# Altium schematic sheet RTLS_Sch.SchDoc
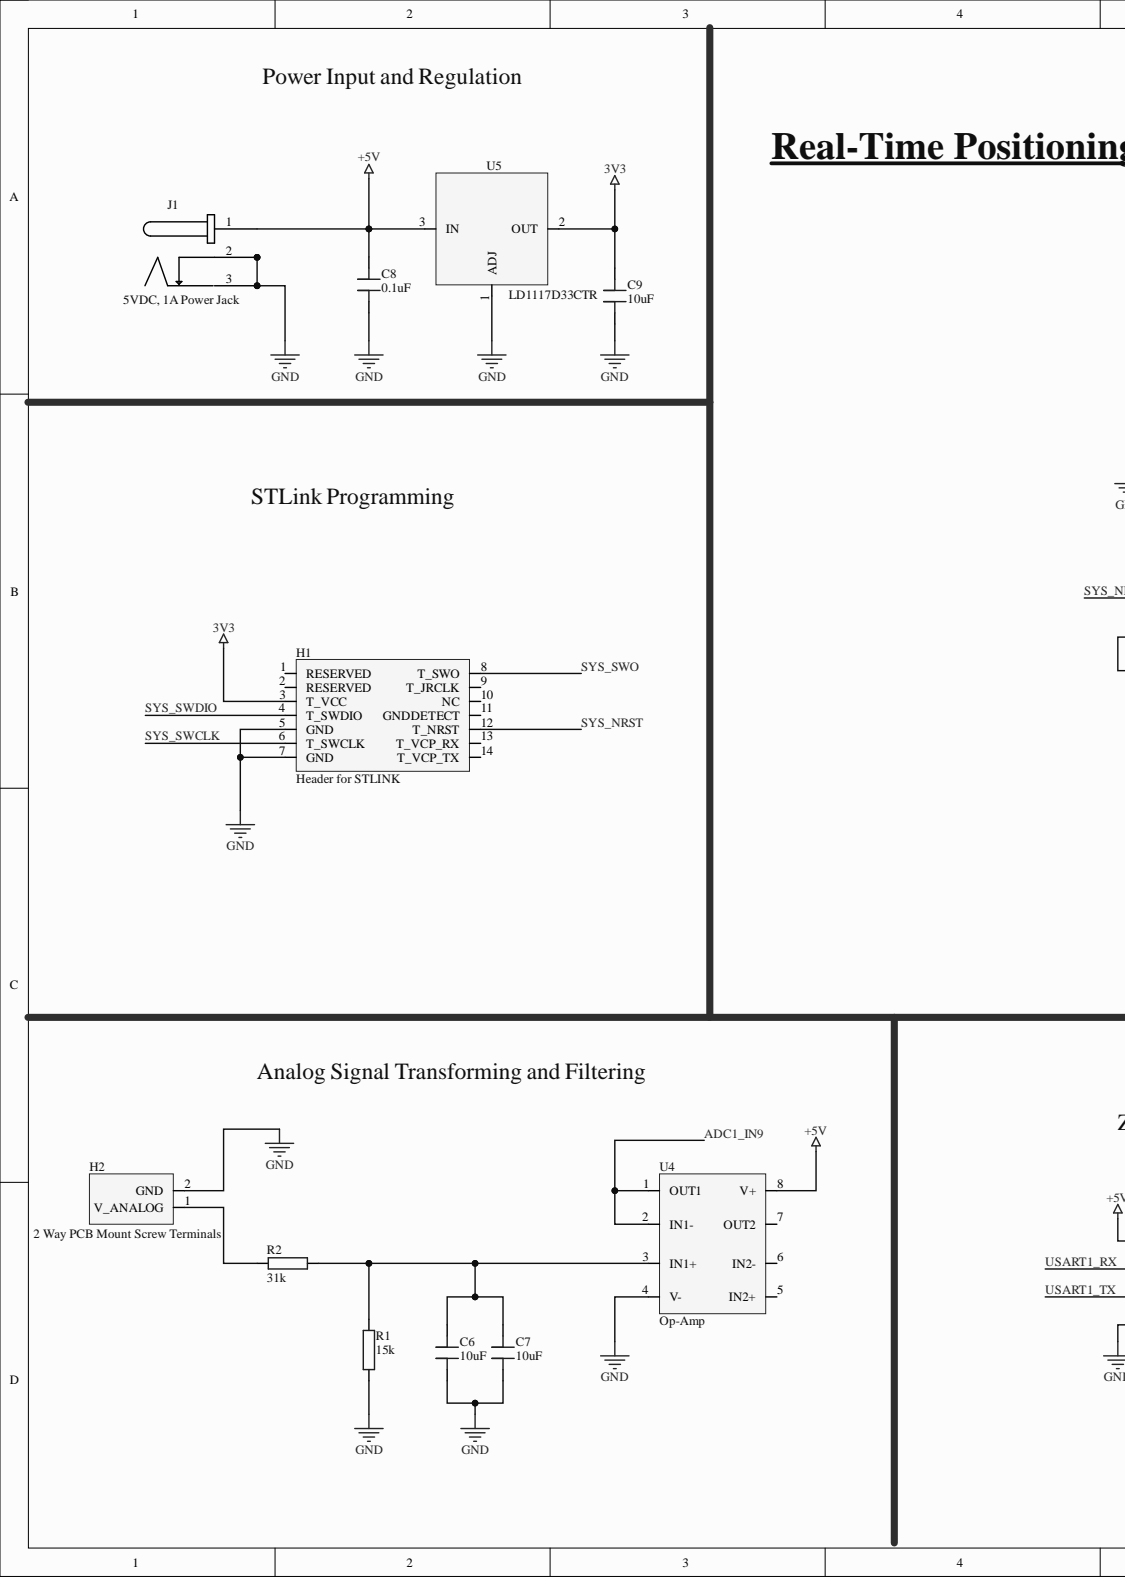
[diagram p0: RTLS_Sch.SchDoc, left]
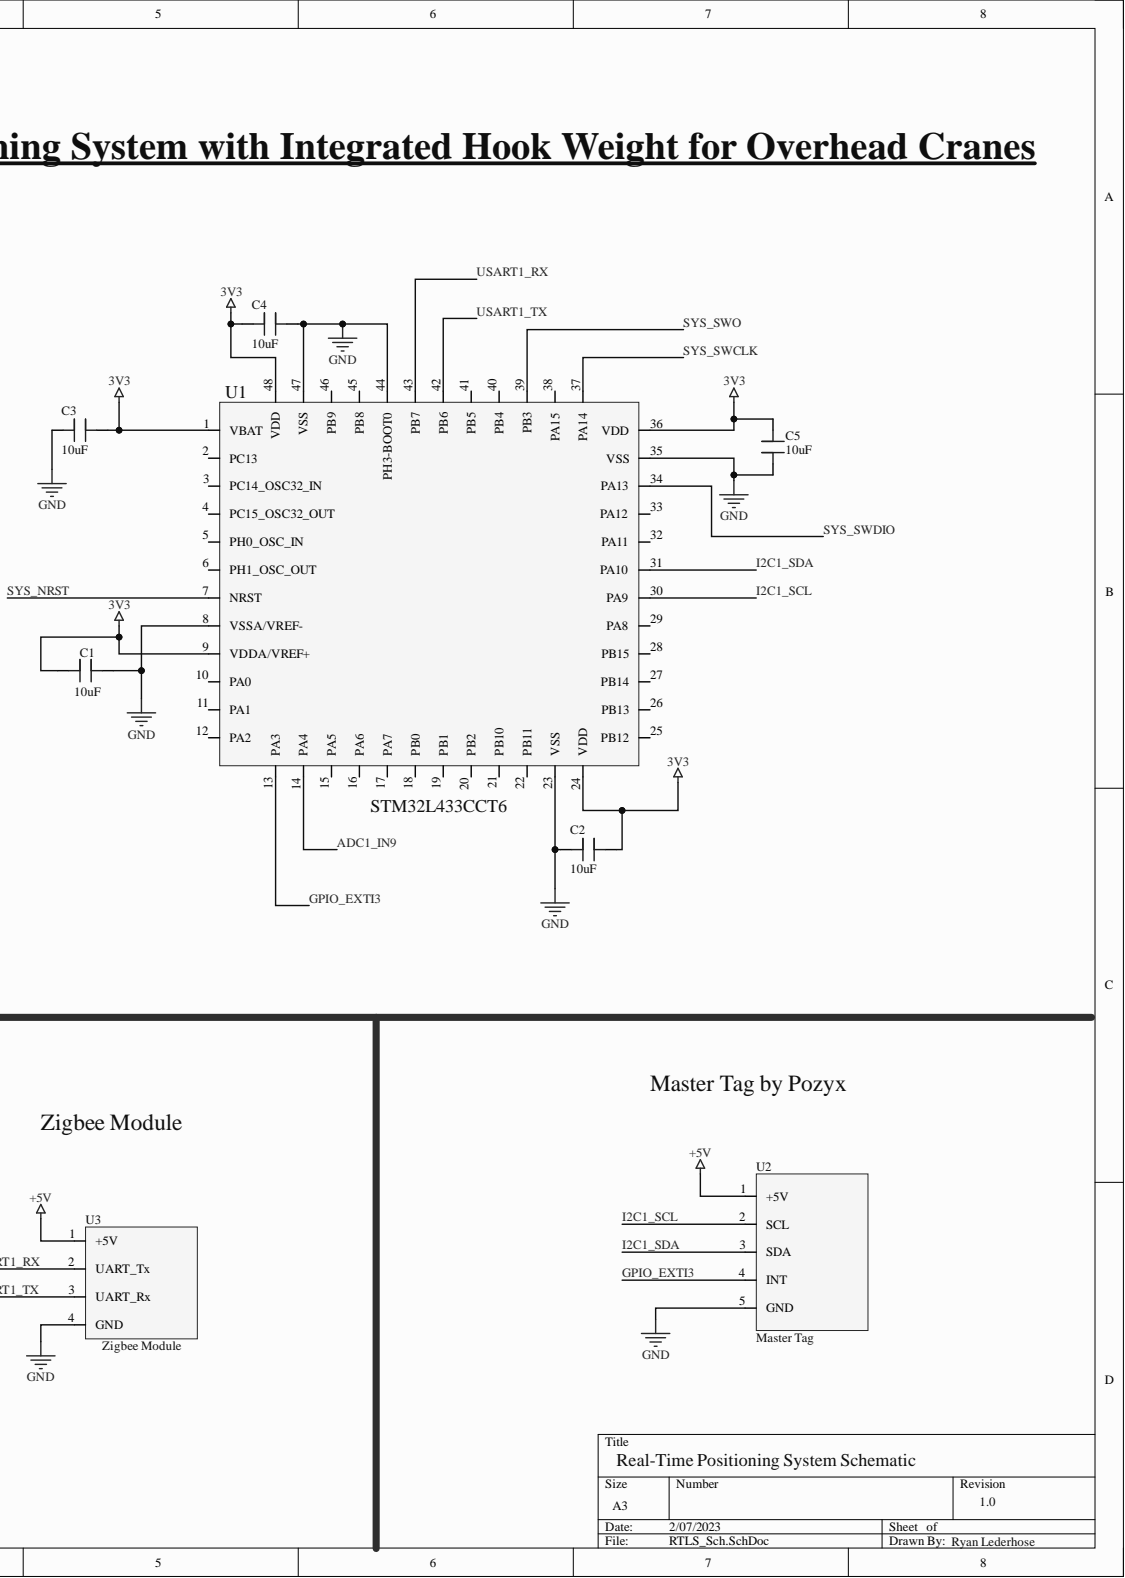
[diagram p1: RTLS_Sch.SchDoc, right]

## Parts
C1  10uF  [10uF Ceramic Capacitor-Footprint-1]
C2  10uF  [10uF Ceramic Capacitor-Footprint-1]
C3  10uF  [10uF Ceramic Capacitor-Footprint-1]
C4  10uF  [10uF Ceramic Capacitor-Footprint-1]
C5  10uF  [10uF Ceramic Capacitor-Footprint-1]
C6  10uF  [10uF Ceramic Capacitor-Footprint-1]
C7  10uF  [10uF Ceramic Capacitor-Footprint-1]
C8  0.1uF  [0.1uF Capacitor-Footprint-1]
C9  10uF  [10uF Ceramic Capacitor-Footprint-1]
H1  Header for STLINK  [Header for STLINK-Footprint-1]
H2  2 Way PCB Mount Screw Terminals  [2 Way PCB Mount Screw Terminals-Footprint-1]
J1  5VDC, 1A Power Jack  [DC power jack-Footprint-1]
R1  15k  [15k Resistor-Footprint-1]
R2  31k  [31k Resistor-Footprint-1]
U1  STM32L433CCT6  [STM32L433CCT6-Footprint-1]
U2  Master Tag  [Master Tag-Footprint-1]
U3  Zigbee Module  [Zigbee by M5Stack-Footprint-1]
U4  Op-Amp  [Op-Amp-Footprint-1]
U5  LD1117D33CTR  [3.3V voltage regulator-Footprint-1]

## Nets
3V3: C1.1 C2.2 C3.2 C4.1 C5.2 C9.2 H1.3(T_VCC) U1.1(VBAT) U1.9(VDDA/VREF+) U1.24(VDD) U1.36(VDD) U1.48(VDD) U5.2
+5V: C8.2 J1.1 U2.1(+5V) U3.1(+5V) U4.8(V+) U5.3
GND: C1.2 C2.1 C3.1 C4.2 C5.1 C6.1 C7.1 C8.1 C9.1 H1.5(GND) H1.7(GND) H2.2 J1.2 J1.3 R1.1 U1.8(VSSA/VREF-) U1.23(VSS) U1.35(VSS) U1.44(PH3-BOOT0) U1.47(VSS) U2.5(GND) U3.4(GND) U4.4(V-) U5.1
ADC1_IN9: U1.14(PA4) U4.1(OUT1) U4.2(IN1-)
GPIO_EXTI3: U1.13(PA3) U2.4(INT)
I2C1_SCL: U1.30(PA9) U2.2(SCL)
I2C1_SDA: U1.31(PA10) U2.3(SDA)
SYS_NRST: H1.12(T_NRST) U1.7(NRST)
SYS_SWCLK: H1.6(T_SWCLK) U1.37(PA14)
SYS_SWDIO: H1.4(T_SWDIO) U1.34(PA13)
SYS_SWO: H1.8(T_SWO) U1.39(PB3)
USART1_RX: U1.43(PB7) U3.2(UART_Tx)
USART1_TX: U1.42(PB6) U3.3(UART_Rx)
NetC6_2: C6.2 C7.2 R1.2 R2.2 U4.3(IN1+)
NetH2_1: H2.1 R2.1

Not connected: H1 1(RESERVED) 2(RESERVED) 9(T_JRCLK) 10(NC) 11(GNDDETECT) 13(T_VCP_RX) 14(T_VCP_TX); U1 2(PC13) 3(PC14_OSC32_IN) 4(PC15_OSC32_OUT) 5(PH0_OSC_IN) 6(PH1_OSC_OUT) 10(PA0) 11(PA1) 12(PA2) 15(PA5) 16(PA6) 17(PA7) 18(PB0) 19(PB1) 20(PB2) 21(PB10) 22(PB11) 25(PB12) 26(PB13) 27(PB14) 28(PB15) 29(PA8) 32(PA11) 33(PA12) 38(PA15) 40(PB4) 41(PB5) 45(PB8) 46(PB9); U4 5(IN2+) 6(IN2-) 7(OUT2)

## Library data of the parts
C1-C7 C9 = CMP-u19snyweuh8b17ctr4lk-3: 10uF Capacitor; Component Kind: Standard; CustomComponentID: u19snyweuh8b17ctr4lk; Library Name: My Personal Space
C8 = CMP-5nwq8nwe9jr3ztimp7ur-2: 0.1uF ceramic capacitor; Component Kind: Standard; CustomComponentID: 5nwq8nwe9jr3ztimp7ur; Library Name: My Personal Space
H1 = CMP-7va66yqy350wnqftx4dx-1: STLINK is a programmer; Component Kind: Standard; CustomComponentID: 7va66yqy350wnqftx4dx; Library Name: My Personal Space
H2 = CMP-tc9wcc6bmremsx6bdgbj-1: 2 Way PCB Mount Screw Terminals 5mm pitch; Component Kind: Standard; CustomComponentID: tc9wcc6bmremsx6bdgbj; Library Name: My Personal Space
J1 = CMP-g5aq2d2r79yioz7w6vxa-1: power jack; Component Kind: Standard; CustomComponentID: g5aq2d2r79yioz7w6vxa; Library Name: My Personal Space
R1 = CMP-1etme218qaf0bcuam6j3-3: 15k Through-hole Resistor; Component Kind: Standard; CustomComponentID: 1etme218qaf0bcuam6j3; Library Name: My Personal Space
R2 = CMP-wm8lq4d4767nvzvsrvzc-2: Component Kind: Standard; CustomComponentID: wm8lq4d4767nvzvsrvzc; Description: 31k; Library Name: My Personal Space
U1 = CMP-e6ib9t1lwoihxnboj2bn-2: Microcontroller for PCB; Component Kind: Standard; CustomComponentID: e6ib9t1lwoihxnboj2bn; Library Name: My Personal Space
U2 = CMP-xo3v5r0gbr0wz4docfmo-3: Master Tag used for Conducting Positioning; Component Kind: Standard; CustomComponentID: xo3v5r0gbr0wz4docfmo; Library Name: My Personal Space
U3 = CMP-lz4frr8nx0ysg47o9abs-4: Component Kind: Standard; CustomComponentID: lz4frr8nx0ysg47o9abs; Description: Zigbee Module; Library Name: My Personal Space
U4 = CMP-gvvjga9fwntqdg4lu3fy-2: Op amp for buffering adc input; Component Kind: Standard; CustomComponentID: gvvjga9fwntqdg4lu3fy; Library Name: My Personal Space
U5 = CMP-p2zwwsj49tk05prsr1hx-1: 3.3V 800mA regulator; Component Kind: Standard; CustomComponentID: p2zwwsj49tk05prsr1hx; Library Name: My Personal Space
